# Altium schematic sheet RUMBA_plus.SchDoc
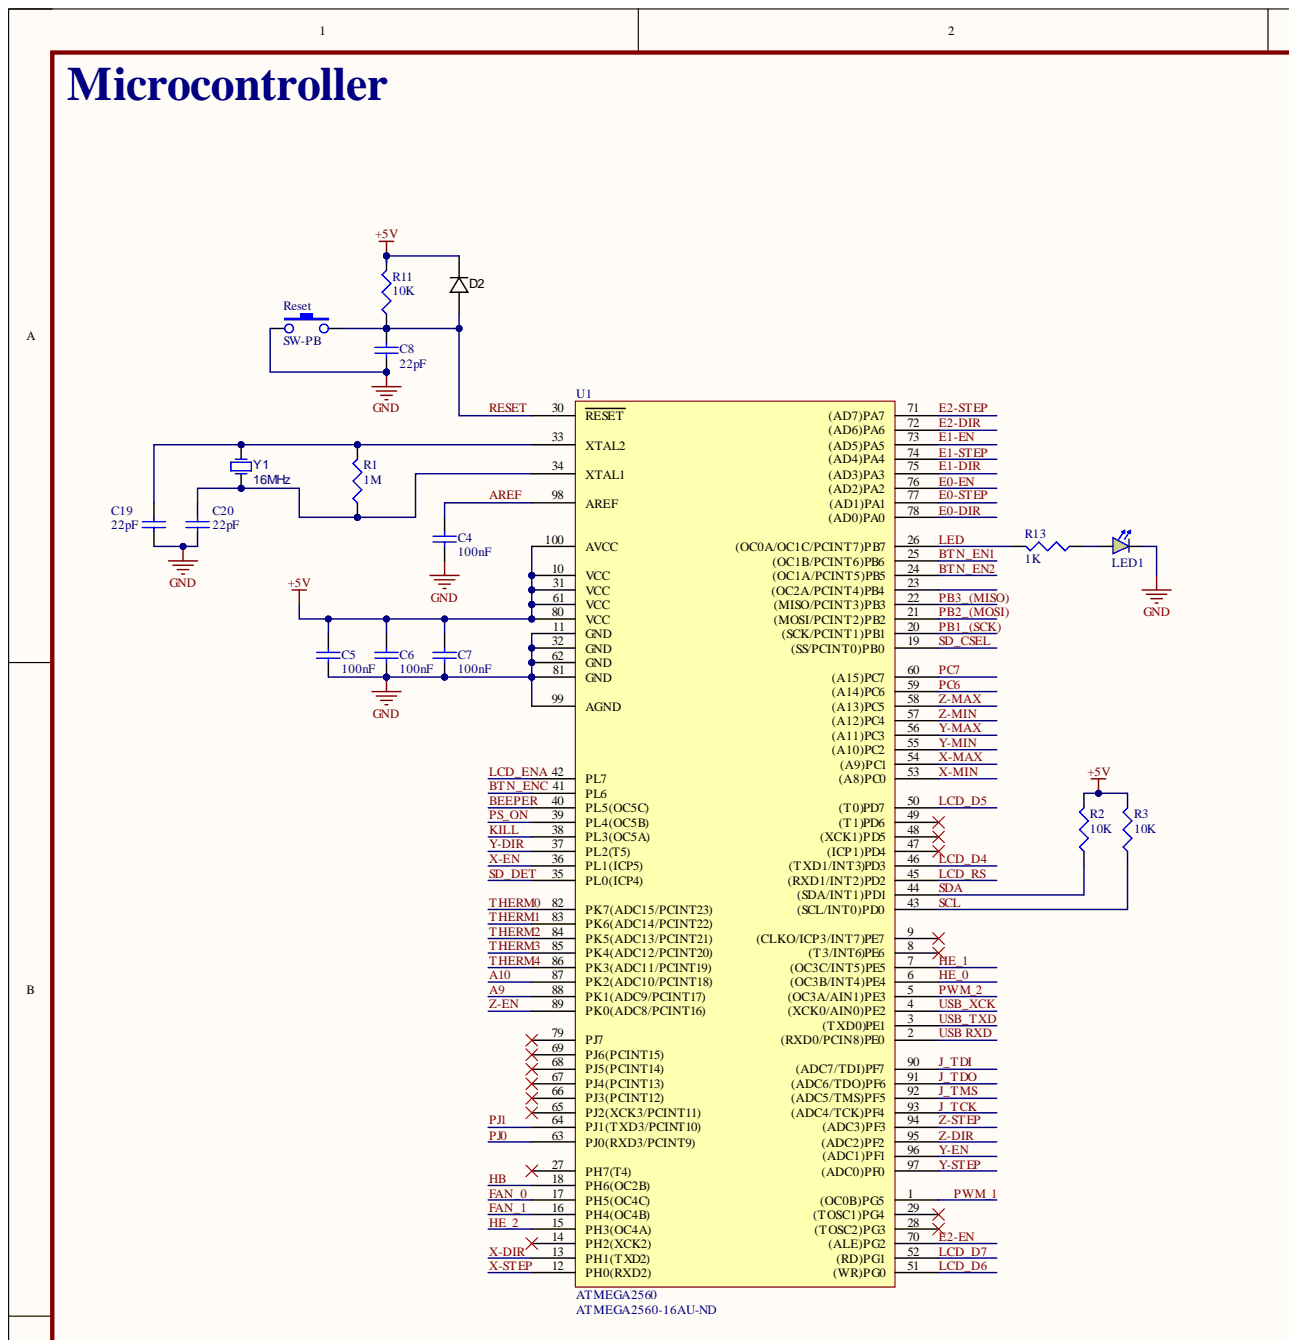
[diagram p0: RUMBA_plus.SchDoc, top left]
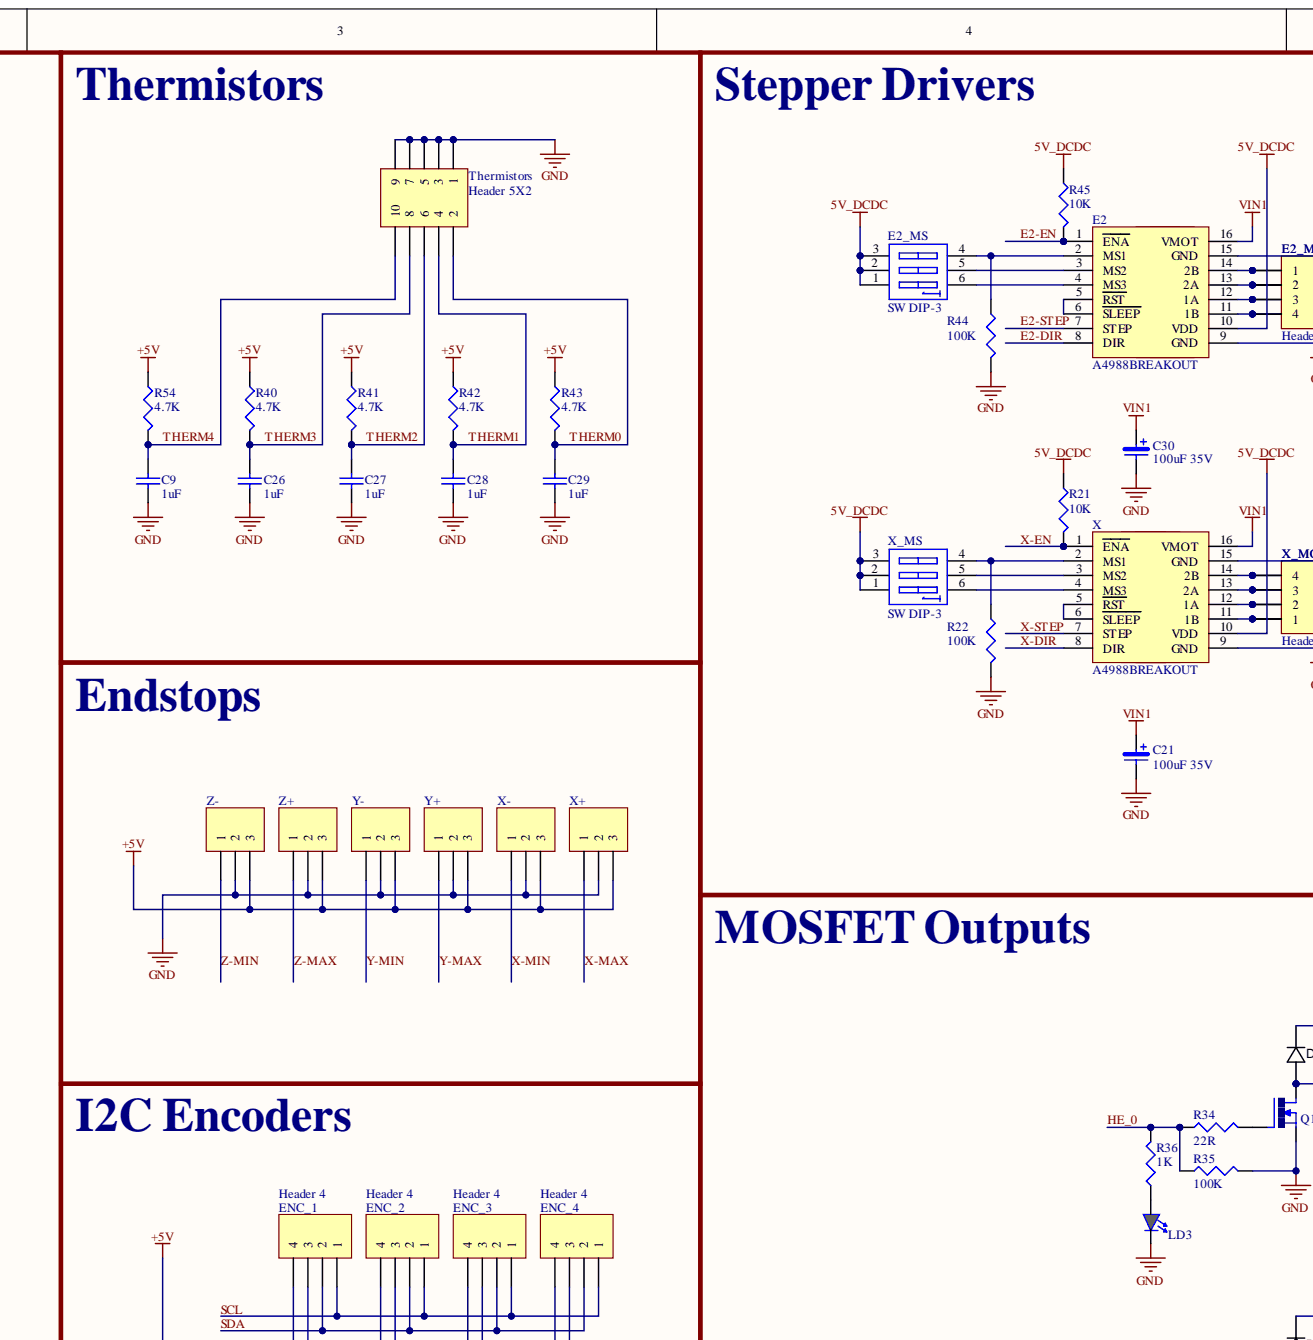
[diagram p1: RUMBA_plus.SchDoc, top centre]
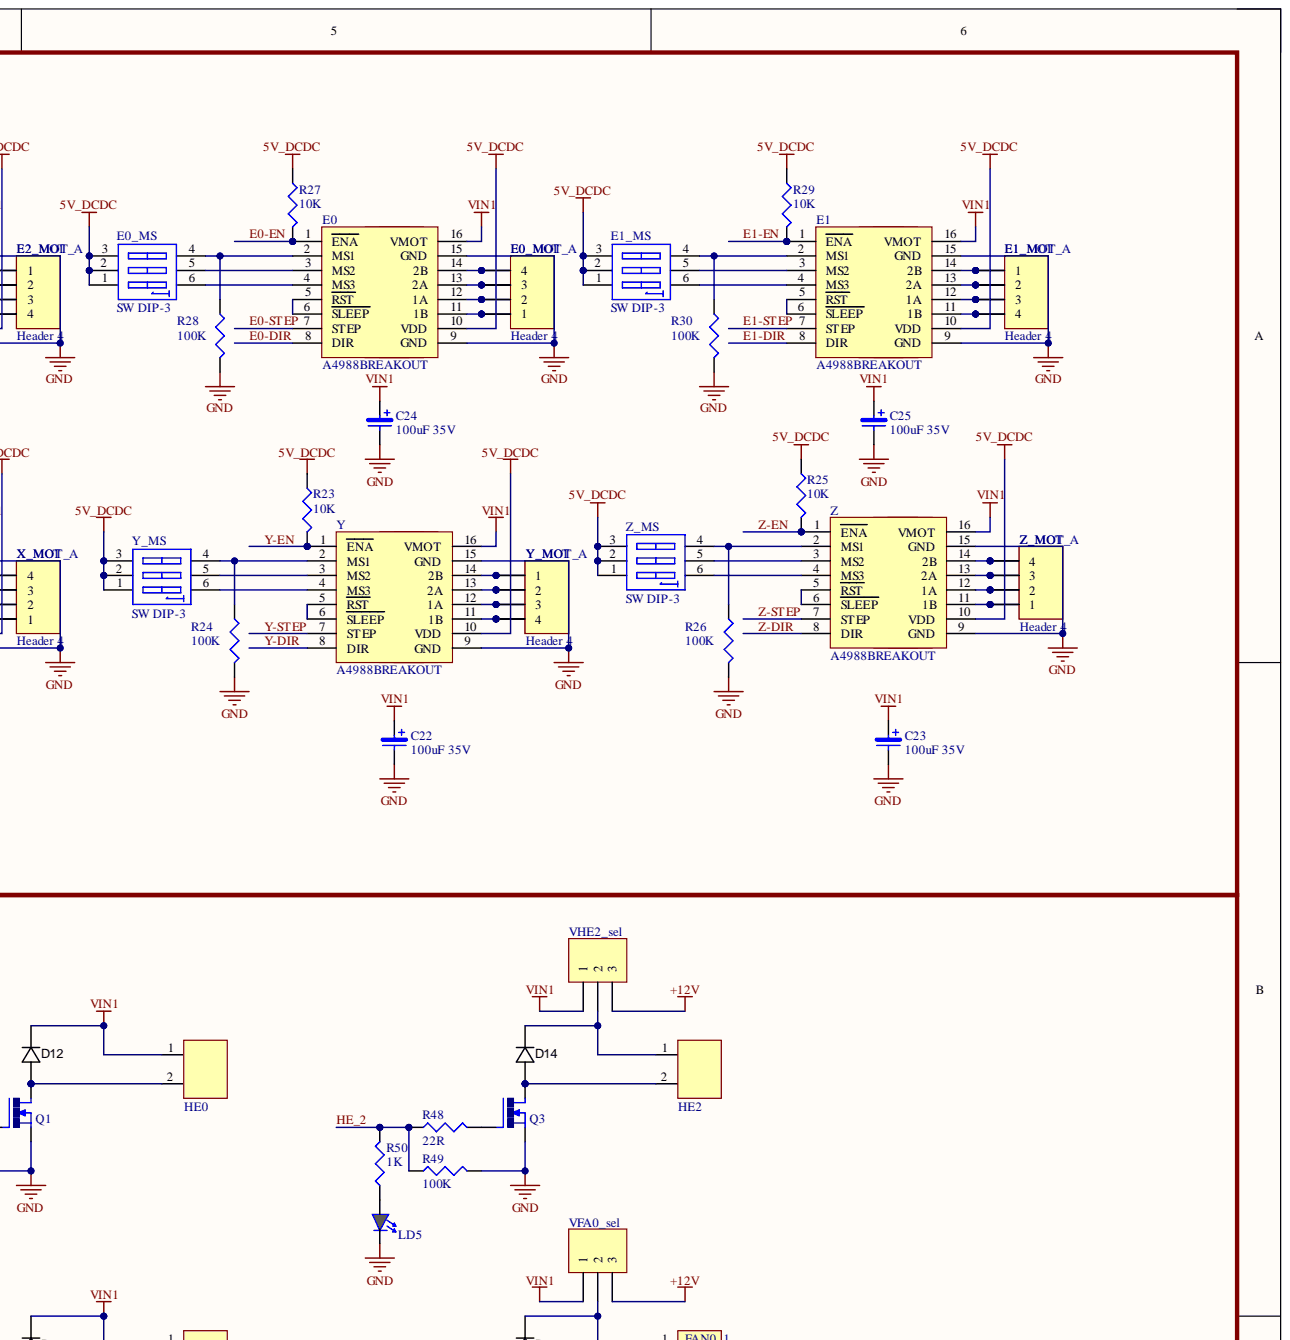
[diagram p2: RUMBA_plus.SchDoc, top right]
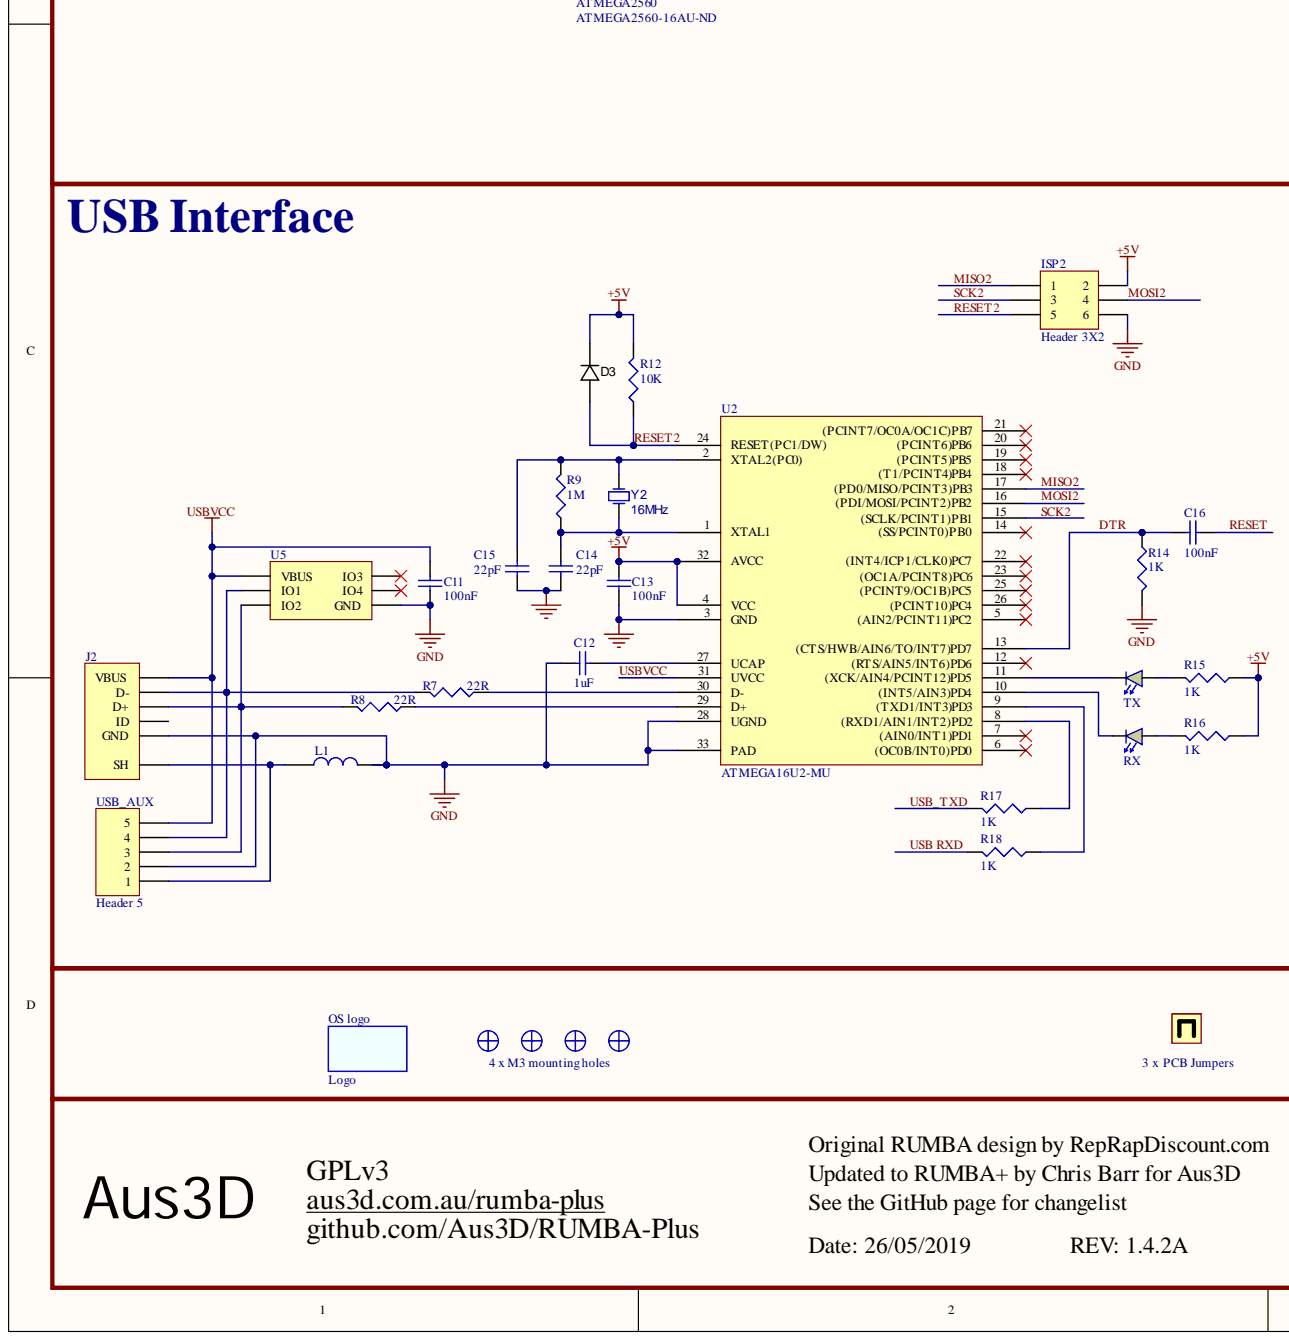
[diagram p3: RUMBA_plus.SchDoc, bottom left]
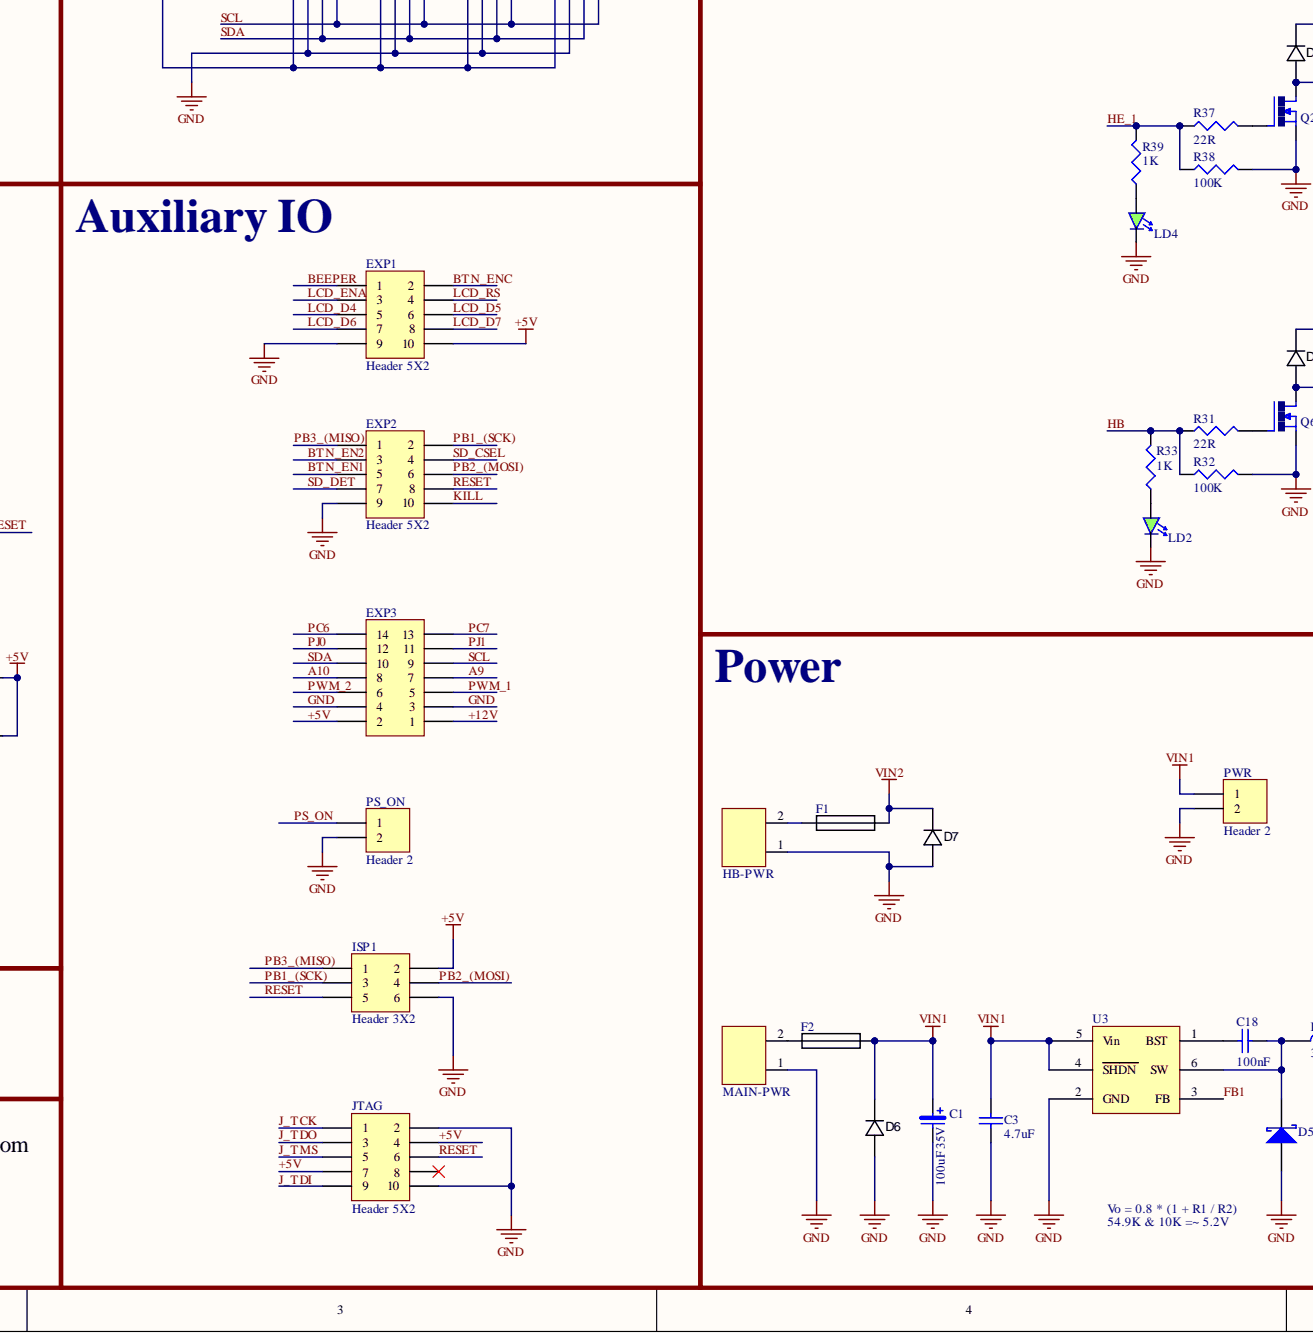
[diagram p4: RUMBA_plus.SchDoc, bottom centre]
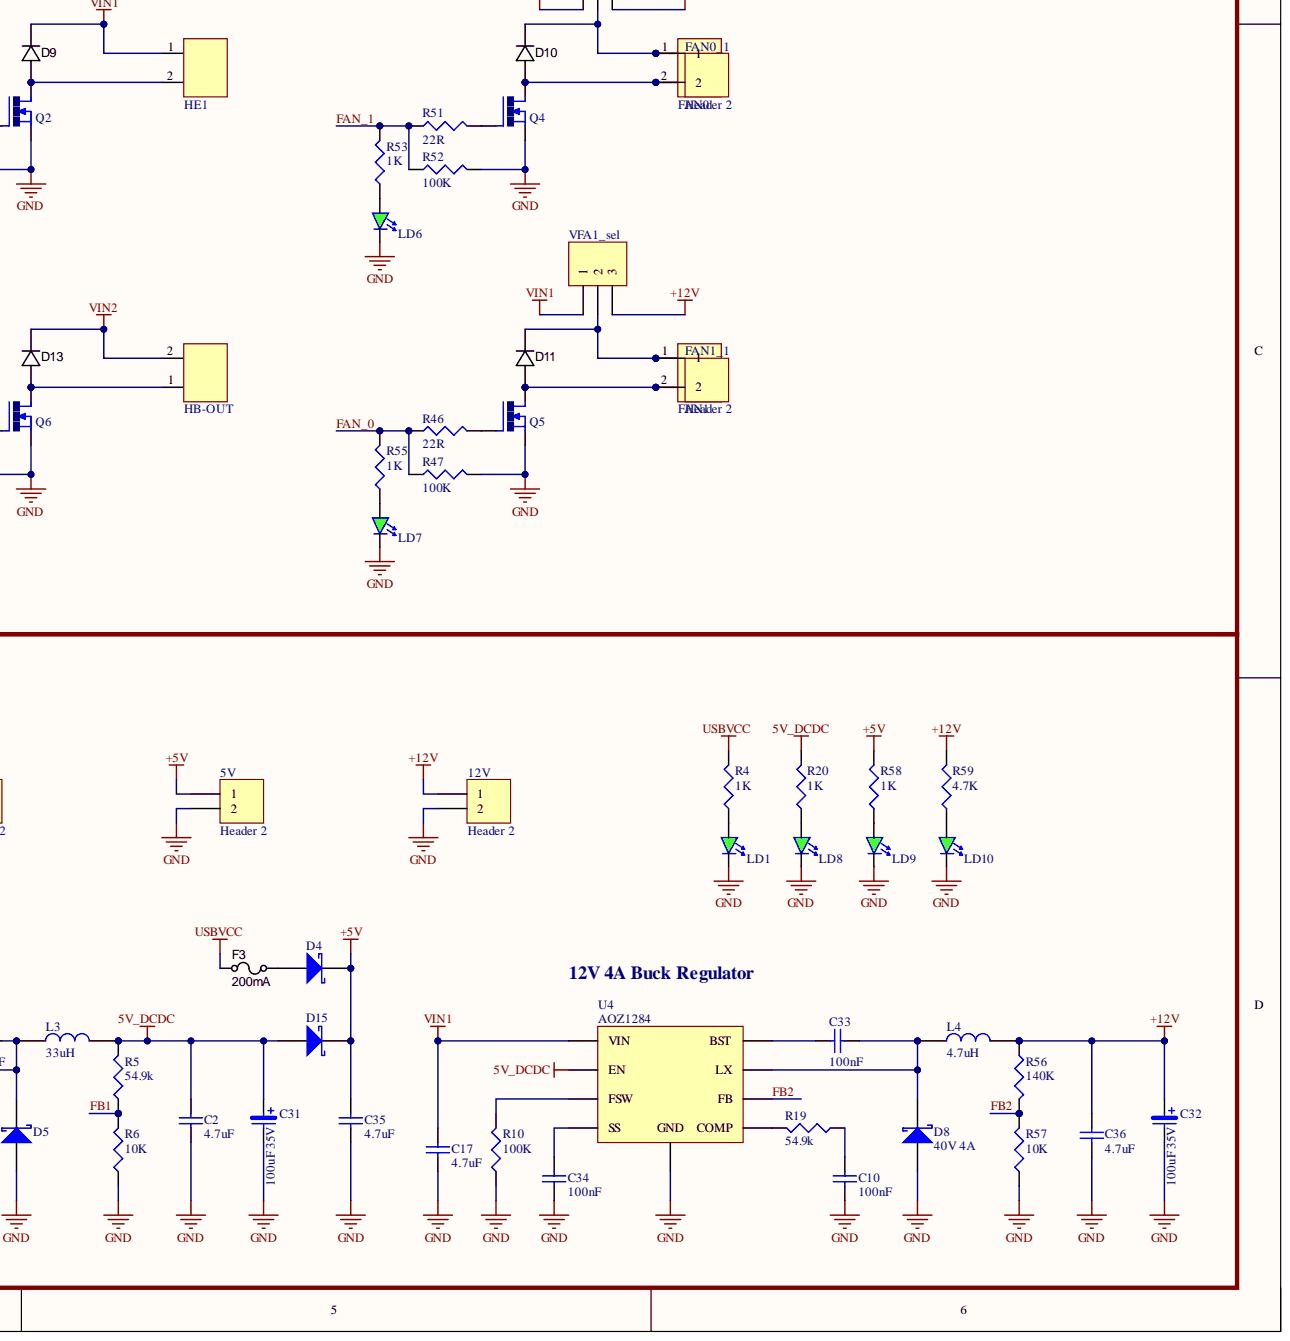
[diagram p5: RUMBA_plus.SchDoc, bottom right]

## Parts
5V  Header 2  [HDR1X2]
12V  Header 2  [HDR1X2]
C1  value 100uF 35V  [CAP EL PANASONIC]
C2  value 4.7uF  [CAPC2012X135N]
C3  value 4.7uF  [CAP 3225-1210]
C4  value 100nF  [Cap 1608-0603]
C5  value 100nF  [Cap 1608-0603]
C6  value 100nF  [Cap 1608-0603]
C7  value 100nF  [Cap 1608-0603]
C8  value 22pF  [Cap 1608-0603]
C9  value 1uF  [Cap 1608-0603]
C10  value 100nF  [Cap 1608-0603]
C11  value 100nF  [Cap 1608-0603]
C12  value 1uF  [Cap 1608-0603]
C13  value 100nF  [Cap 1608-0603]
C14  value 22pF  [Cap 1608-0603]
C15  value 22pF  [Cap 1608-0603]
C16  value 100nF  [Cap 1608-0603]
C17  value 4.7uF  [CAP 3225-1210]
C18  value 100nF  [Cap 1608-0603]
C19  value 22pF  [Cap 1608-0603]
C20  value 22pF  [Cap 1608-0603]
C21  value 100uF 35V  [CAP EL PANASONIC]
C22  value 100uF 35V  [CAP EL PANASONIC]
C23  value 100uF 35V  [CAP EL PANASONIC]
C24  value 100uF 35V  [CAP EL PANASONIC]
C25  value 100uF 35V  [CAP EL PANASONIC]
C26  value 1uF  [Cap 1608-0603]
C27  value 1uF  [Cap 1608-0603]
C28  value 1uF  [Cap 1608-0603]
C29  value 1uF  [Cap 1608-0603]
C30  value 100uF 35V  [CAP EL PANASONIC]
C31  value 100uF 35V  [CAP EL PANASONIC]
C32  value 100uF 35V  [CAP EL PANASONIC]
C33  value 100nF  [Cap 1608-0603]
C34  value 100nF  [Cap 1608-0603]
C35  value 4.7uF  [CAPC2012X135N]
C36  value 4.7uF  [CAP 3225-1210]
D2  (no value)  [SOD323]
D3  (no value)  [SOD323]
D4  (no value)  [DIOM2616X08N]
D5  (no value)  [DIOM2616X08N]
D6  (no value)  [DO-214AC]
D7  (no value)  [DO-214AC]
D8  value 40V 4A  [DO-214AA]
D9  (no value)  [DO-214AC]
D10  (no value)  [DO-214AC]
D11  (no value)  [DO-214AC]
D12  (no value)  [DO-214AC]
D13  (no value)  [DO-214AC]
D14  (no value)  [DO-214AC]
D15  (no value)  [DIOM2616X08N]
E0  A4988BREAKOUT  [A4988BREAKOUT]  (no BOM)
E0_0  (no value)  [HDR1X8-HEADER]  (mechanical)
E0_1  (no value)  [HDR1X8-HEADER]  (mechanical)
E0_MOT  Header 4  [HDR1X4]
E0_MOT_A  (no value)  [TERM4 3.5mm]
E0_MS  SW DIP-3  [dipsw SO6]
E1  A4988BREAKOUT  [A4988BREAKOUT]  (no BOM)
E1_0  (no value)  [HDR1X8-HEADER]  (mechanical)
E1_1  (no value)  [HDR1X8-HEADER]  (mechanical)
E1_MOT  Header 4  [HDR1X4]
E1_MOT_A  (no value)  [TERM4 3.5mm]
E1_MS  SW DIP-3  [dipsw SO6]
E2  A4988BREAKOUT  [A4988BREAKOUT]  (no BOM)
E2_0  (no value)  [HDR1X8-HEADER]  (mechanical)
E2_1  (no value)  [HDR1X8-HEADER]  (mechanical)
E2_MOT  Header 4  [HDR1X4]
E2_MOT_A  (no value)  [TERM4 3.5mm]
E2_MS  SW DIP-3  [dipsw SO6]
ENC_1  Header 4  [HDR1X4]
ENC_2  Header 4  [HDR1X4]
ENC_3  Header 4  [HDR1X4]
ENC_4  Header 4  [HDR1X4]
EXP1  Header 5X2  [HDR2X5_exp Iner only\]
EXP2  Header 5X2  [HDR2X5_exp Iner only\]
EXP3  Header 7X2  [HDR2X7]  (value not drawn)
F1  (no value)  [Fuseholder - BK-6013 - Mini ATC ATO Blade 15A]
F2  (no value)  [Fuseholder - BK-6013 - Mini ATC ATO Blade 15A]
F3  value 200mA  [PTC Fuse1608-0603]
FAN0  Header 2  [HDR1X2]
FAN0_1  (no value)  [TERM2 3.5mm]
FAN1  Header 2  [HDR1X2]
FAN1_1  (no value)  [TERM2 3.5mm]
HB-OUT  (no value)  [TERM2 5MM]
HB-PWR  (no value)  [TERM2 5MM]
HE0  (no value)  [TERM2 3.5mm]
HE1  (no value)  [TERM2 3.5mm]
HE2  (no value)  [TERM2 3.5mm]
ISP1  Header 3X2  [HDR2X3]
ISP2  Header 3X2  [HDR2X3]
J2  (no value)  [Micro-USB B Molex 105017-0001]
JMP
JMP
JMP
JTAG  Header 5X2  [HDR2X5]
L1  (no value)  [Res 2012-0805]
L3  value 33uH  [SRN6045TA SMD Inductor]
L4  value 4.7uH  [SRN6045TA SMD Inductor]
LD1  (no value)  [LED 1608-0603]
LD2  (no value)  [LED 1608-0603]
LD3  (no value)  [LED 1608-0603]
LD4  (no value)  [LED 1608-0603]
LD5  (no value)  [LED 1608-0603]
LD6  (no value)  [LED 1608-0603]
LD7  (no value)  [LED 1608-0603]
LD8  (no value)  [LED 1608-0603]
LD9  (no value)  [LED 1608-0603]
LD10  (no value)  [LED 1608-0603]
LED1  (no value)  [LED 1608-0603]
MAIN-PWR  (no value)  [TERM2 5MM]
OS_logo  Logo  [OS LOGO TOP]  (no BOM)
PS_ON  Header 2  [HDR1X2]
PWR  Header 2  [HDR1X2]
Q1  (no value)  [LFPACK]
Q2  (no value)  [LFPACK]
Q3  (no value)  [LFPACK]
Q4  (no value)  [LFPACK]
Q5  (no value)  [LFPACK]
Q6  (no value)  [LFPACK]
R1  value 1M  [RES 1608-0603]
R2  value 10K  [RES 1608-0603]
R3  value 10K  [RES 1608-0603]
R4  value 1K  [RES 1608-0603]
R5  value 54.9k  [RES 1608-0603]
R6  value 10K  [RES 1608-0603]
R7  value 22R  [RES 1608-0603]
R8  value 22R  [RES 1608-0603]
R9  value 1M  [RES 1608-0603]
R10  value 100K  [RES 1608-0603]
R11  value 10K  [RES 1608-0603]
R12  value 10K  [RES 1608-0603]
R13  value 1K  [RES 1608-0603]
R14  value 1K  [RES 1608-0603]
R15  value 1K  [RES 1608-0603]
R16  value 1K  [RES 1608-0603]
R17  value 1K  [RES 1608-0603]
R18  value 1K  [RES 1608-0603]
R19  value 54.9k  [RES 1608-0603]
R20  value 1K  [RES 1608-0603]
R21  value 10K  [RES 1608-0603]
R22  value 100K  [RES 1608-0603]
R23  value 10K  [RES 1608-0603]
R24  value 100K  [RES 1608-0603]
R25  value 10K  [RES 1608-0603]
R26  value 100K  [RES 1608-0603]
R27  value 10K  [RES 1608-0603]
R28  value 100K  [RES 1608-0603]
R29  value 10K  [RES 1608-0603]
R30  value 100K  [RES 1608-0603]
R31  value 22R  [RES 1608-0603]
R32  value 100K  [RES 1608-0603]
R33  value 1K  [RES 1608-0603]
R34  value 22R  [RES 1608-0603]
R35  value 100K  [RES 1608-0603]
R36  value 1K  [RES 1608-0603]
R37  value 22R  [RES 1608-0603]
R38  value 100K  [RES 1608-0603]
R39  value 1K  [RES 1608-0603]
R40  value 4.7K  [RES 1608-0603]
R41  value 4.7K  [RES 1608-0603]
R42  value 4.7K  [RES 1608-0603]
R43  value 4.7K  [RES 1608-0603]
R44  value 100K  [RES 1608-0603]
R45  value 10K  [RES 1608-0603]
R46  value 22R  [RES 1608-0603]
R47  value 100K  [RES 1608-0603]
R48  value 22R  [RES 1608-0603]
R49  value 100K  [RES 1608-0603]
R50  value 1K  [RES 1608-0603]
R51  value 22R  [RES 1608-0603]
R52  value 100K  [RES 1608-0603]
R53  value 1K  [RES 1608-0603]
R54  value 4.7K  [RES 1608-0603]
R55  value 1K  [RES 1608-0603]
R56  value 140K  [RES 1608-0603]
R57  value 10K  [RES 1608-0603]
R58  value 1K  [RES 1608-0603]
R59  value 4.7K  [RES 1608-0603]
Reset  SW-PB  [SW-PB SMD 2pin]
RX  (no value)  [LED 1608-0603]
sc1  Sraf  [Sraf 3mm - rupa 3.2]  (value not drawn)  (no BOM)
sc2  Sraf  [Sraf 3mm - rupa 3.2]  (value not drawn)  (no BOM)
sc3  Sraf  [Sraf 3mm - rupa 3.5]  (value not drawn)  (no BOM)
sc4  Sraf  [Sraf 3mm - rupa 3.5]  (value not drawn)  (no BOM)
Thermistors  Header 5X2  [HDR2X5]
TX  (no value)  [LED 1608-0603]
U1  ATMEGA2560  [TQFP100N]
U2  ATMEGA16U2-MU  [32M1-A_N]
U3  (no value)  [SOT23-6N]
U4  AOZ1284  [SOIC127P600X170_HS-9N]
U5  (no value)  [SOT23-6N]
USB_AUX  Header 5  [HDR1X5-HEADER]
VFA0_sel  Header 3  [HDR1X3]  (value not drawn)
VFA1_sel  Header 3  [HDR1X3]  (value not drawn)
VHE2_sel  Header 3  [HDR1X3]  (value not drawn)
X  A4988BREAKOUT  [A4988BREAKOUT]  (no BOM)
X+  Header 3  [HDR1X3]  (value not drawn)
X-  Header 3  [HDR1X3]  (value not drawn)
X_1  (no value)  [HDR1X8-HEADER]  (mechanical)
X_2  (no value)  [HDR1X8-HEADER]  (mechanical)
X_MOT  Header 4  [HDR1X4]
X_MOT_A  (no value)  [TERM4 3.5mm]
X_MS  SW DIP-3  [dipsw SO6]
Y  A4988BREAKOUT  [A4988BREAKOUT]  (no BOM)
Y1  16MHz  [3525 SMD Crystal]
Y2  16MHz  [3525 SMD Crystal]
Y+  Header 3  [HDR1X3]  (value not drawn)
Y-  Header 3  [HDR1X3]  (value not drawn)
Y_0  (no value)  [HDR1X8-HEADER]  (mechanical)
Y_1  (no value)  [HDR1X8-HEADER]  (mechanical)
Y_MOT  Header 4  [HDR1X4]
Y_MOT_A  (no value)  [TERM4 3.5mm]
Y_MS  SW DIP-3  [dipsw SO6]
Z  A4988BREAKOUT  [A4988BREAKOUT]  (no BOM)
Z+  Header 3  [HDR1X3]  (value not drawn)
Z-  Header 3  [HDR1X3]  (value not drawn)
Z_0  (no value)  [HDR1X8-HEADER]  (mechanical)
Z_1  (no value)  [HDR1X8-HEADER]  (mechanical)
Z_MOT  Header 4  [HDR1X4]
Z_MOT_A  (no value)  [TERM4 3.5mm]
Z_MS  SW DIP-3  [dipsw SO6]

## Nets
VIN1: C1.1 C3.2 C17.2 C21.1 C22.1 C23.1 C24.1 C25.1 C30.1 D6.K D9.K D12.K E0.16(VMOT) E1.16(VMOT) E2.16(VMOT) F2.1 HE0.1 HE1.1 PWR.1 U3.4(SHDN) U3.5(Vin) U4.9(VIN) VFA0_sel.1 VFA1_sel.1 VHE2_sel.1 X.16(VMOT) Y.16(VMOT) Z.16(VMOT)
VIN2: D7.K D13.K F1.1 HB-OUT.2
5V_DCDC: 5V.1 C2.2 C5.2 C6.2 C7.2 C13.2 C31.1 C35.2 D2.K D3.K D4.K D15.A D15.K E0.10(7_VDD) E0_MS.1 E0_MS.2 E0_MS.3 E1.10(7_VDD) E1_MS.1 E1_MS.2 E1_MS.3 E2.10(7_VDD) E2_MS.1 E2_MS.2 E2_MS.3 ENC_1.4 ENC_2.4 ENC_3.4 ENC_4.4 EXP1.10 EXP3.2 ISP1.2 ISP2.2 JTAG.4 JTAG.7 L3.2 R2.2 R3.2 R5.2 R11.2 R12.2 R15.1 R16.1 R20.2 R21.1 R23.1 R25.1 R27.1 R29.1 R40.1 R41.1 R42.1 R43.1 R45.1 R54.1 R58.2 U1.10(VCC) U1.31(VCC) U1.61(VCC) U1.80(VCC) U1.100(AVCC) U2.4(VCC) U2.32(AVCC) U4.8(EN) X.10(VDD) X+.3 X-.3 X_MS.1 X_MS.2 X_MS.3 Y.10(7_VDD) Y+.3 Y-.3 Y_MS.1 Y_MS.2 Y_MS.3 Z.10(7_VDD) Z+.3 Z-.3 Z_MS.1 Z_MS.2 Z_MS.3  [also labelled +5V]
+12V: 12V.1 C32.1 C36.2 EXP3.1 L4.2 R56.1 R59.2 VFA0_sel.3 VFA1_sel.3 VHE2_sel.3
A9: EXP3.7 U1.88(PK1(ADC9/PCINT17))
A10: EXP3.8 U1.87(PK2(ADC10/PCINT18))
AREF: C4.2 U1.98(AREF)
BEEPER: EXP1.1 U1.40(PL5(OC5C))
BTN_EN1: EXP2.5 U1.25((OC1B/PCINT6)PB6)
BTN_EN2: EXP2.3 U1.24((OC1A/PCINT5)PB5)
BTN_ENC: EXP1.2 U1.41(PL6)
DTR: C16.2 R14.1 U2.13((CT_S/HWB/AIN6/TO/INT7)PD7)
E0-DIR: E0.8(DIR) U1.78((AD0)PA0)
E0-EN: E0.1(ENA) R27.2 U1.76((AD2)PA2)
E0-STEP: E0.7(ST_EP) U1.77((AD1)PA1)
E1-DIR: E1.8(DIR) U1.75((AD3)PA3)
E1-EN: E1.1(ENA) R29.2 U1.73((AD5)PA5)
E1-STEP: E1.7(ST_EP) U1.74((AD4)PA4)
E2-DIR: E2.8(DIR) U1.72((AD6)PA6)
E2-EN: E2.1(ENA) R45.2 U1.70((ALE)PG2)
E2-STEP: E2.7(ST_EP) U1.71((AD7)PA7)
FAN_0: R46.1 R47.1 R55.2 U1.17(PH5(OC4C))
FAN_1: R51.1 R52.1 R53.2 U1.16(PH4(OC4B))
FB1: R5.1 R6.2 U3.3(FB)
FB2: R56.2 R57.2 U4.6(FB)
GND: 5V.2 12V.2 C1.2 C2.1 C3.1 C4.1 C5.1 C6.1 C7.1 C8.1 C9.2 C10.2 C11.1 C12.2 C13.1 C14.1 C15.1 C17.1 C19.1 C20.1 C21.2 C22.2 C23.2 C24.2 C25.2 C26.2 C27.2 C28.2 C29.2 C30.2 C31.2 C32.2 C34.1 C35.1 C36.1 D5.A D6.A D7.A D8.A E0.9(8_GND) E0.15(2_GND) E1.9(8_GND) E1.15(2_GND) E2.9(8_GND) E2.15(2_GND) ENC_1.3 ENC_2.3 ENC_3.3 ENC_4.3 EXP1.9 EXP2.9 EXP3.3 EXP3.4 HB-PWR.1 ISP1.6 ISP2.6 J2.GND JTAG.2 JTAG.10 L1.2 LD1.2 LD2.2 LD3.2 LD4.2 LD5.2 LD6.2 LD7.2 LD8.2 LD9.2 LD10.2 LED1.2 MAIN-PWR.1 PS_ON.2 PWR.2 Q1.3 Q2.3 Q3.3 Q4.3 Q5.3 Q6.3 R6.1 R10.1 R14.2 R22.2 R24.2 R26.2 R28.2 R30.2 R32.2 R35.2 R38.2 R44.2 R47.2 R49.2 R52.2 R57.1 Reset.1 Thermistors.1 Thermistors.3 Thermistors.5 Thermistors.7 Thermistors.9 U1.11(GND) U1.32(GND) U1.62(GND) U1.81(GND) U1.99(AGND) U2.3(GND) U2.28(UGND) U2.33(PAD) U3.2(GND) U4.3 U5.2(GND) USB_AUX.2 X.9(GND) X.15(GND) X+.2 X-.2 Y.9(8_GND) Y.15(2_GND) Y+.2 Y-.2 Z.9(8_GND) Z.15(2_GND) Z+.2 Z-.2
HB: R31.1 R32.1 R33.2 U1.18(PH6(OC2B))
HE_0: R34.1 R35.1 R36.2 U1.6((OC3B/INT4)PE4)
HE_1: R37.1 R38.1 R39.2 U1.7((OC3C/INT5)PE5)
HE_2: R48.1 R49.1 R50.2 U1.15(PH3(OC4A))
J_TCK: JTAG.1 U1.93((ADC4/TCK)PF4)
J_TDI: JTAG.9 U1.90((ADC7/TDI)PF7)
J_TDO: JTAG.3 U1.91((ADC6/TDO)PF6)
J_TMS: JTAG.5 U1.92((ADC5/TMS)PF5)
KILL: EXP2.10 U1.38(PL3(OC5A))
LCD_D4: EXP1.5 U1.46((T_XD1/INT3)PD3)
LCD_D5: EXP1.6 U1.50((T_0)PD7)
LCD_D6: EXP1.7 U1.51((WR)PG0)
LCD_D7: EXP1.8 U1.52((RD)PG1)
LCD_ENA: EXP1.3 U1.42(PL7)
LCD_RS: EXP1.4 U1.45((RXD1/INT2)PD2)
LED: R13.2 U1.26((OC0A/OC1C/PCINT7)PB7)
MISO2: ISP2.1 U2.17((PD0/MISO/PCINT3)PB3)
MOSI2: ISP2.4 U2.16((PDI/MOSI/PCINT2)PB2)
PB1_(SCK): EXP2.2 ISP1.3 U1.20((SCK/PCINT1)PB1)
PB2_(MOSI): EXP2.6 ISP1.4 U1.21((MOSI/PCINT2)PB2)
PB3_(MISO): EXP2.1 ISP1.1 U1.22((MISO/PCINT3)PB3)
PC6: EXP3.14 U1.59((A14)PC6)
PC7: EXP3.13 U1.60((A15)PC7)
PJ0: EXP3.12 U1.63(PJ0(RXD3/PCINT9))
PJ1: EXP3.11 U1.64(PJ1(T_XD3/PCINT10))
PS_ON: PS_ON.1 U1.39(PL4(OC5B))
PWM_1: EXP3.5 U1.1((OC0B)PG5)
PWM_2: EXP3.6 U1.5((OC3A/AIN1)PE3)
RESET: C8.2 C16.1 D2.A EXP2.8 ISP1.5 JTAG.6 R11.1 Reset.2 U1.30(RESET)
RESET2: D3.A ISP2.5 R12.1 U2.24(RESET_(PC1/DW))
SCK2: ISP2.3 U2.15((SCLK/PCINT1)PB1)
SCL: ENC_1.1 ENC_2.1 ENC_3.1 ENC_4.1 EXP3.9 R3.1 U1.43((SCL/INT0)PD0)
SD_CSEL: EXP2.4 U1.19((SS/PCINT0)PB0)
SD_DET: EXP2.7 U1.35(PL0(ICP4))
SDA: ENC_1.2 ENC_2.2 ENC_3.2 ENC_4.2 EXP3.10 R2.1 U1.44((SDA/INT1)PD1)
THERM0: C29.1 R43.2 Thermistors.2 U1.82(PK7(ADC15/PCINT23))
THERM1: C28.1 R42.2 Thermistors.4 U1.83(PK6(ADC14/PCINT22))
THERM2: C27.1 R41.2 Thermistors.6 U1.84(PK5(ADC13/PCINT21))
THERM3: C26.1 R40.2 Thermistors.8 U1.85(PK4(ADC12/PCINT20))
THERM4: C9.1 R54.2 Thermistors.10 U1.86(PK3(ADC11/PCINT19))
USB RXD: R18.2 U1.2((RXD0/PCIN8)PE0)
USB_TXD: R17.2 U1.3((T_XD0)PE1)
USB_XCK: U1.4((XCK0/AIN0)PE2)
USBVCC: C11.2 F3.1 J2.VBUS R4.2 U2.31(UVCC) U5.5(VBUS) USB_AUX.5
X-DIR: U1.13(PH1(T_XD2)) X.8(DIR)
X-EN: R21.2 U1.36(PL1(ICP5)) X.1(ENA_1)
X-MAX: U1.54((A9)PC1) X+.1
X-MIN: U1.53((A8)PC0) X-.1
X-STEP: U1.12(PH0(RXD2)) X.7(ST_EP_7)
Y-DIR: U1.37(PL2(T5)) Y.8(DIR)
Y-EN: R23.2 U1.96((ADC1)PF1) Y.1(ENA)
Y-MAX: U1.56((A11)PC3) Y+.1
Y-MIN: U1.55((A10)PC2) Y-.1
Y-STEP: U1.97((ADC0)PF0) Y.7(ST_EP)
Z-DIR: U1.95((ADC2)PF2) Z.8(DIR)
Z-EN: R25.2 U1.89(PK0(ADC8/PCINT16)) Z.1(ENA)
Z-MAX: U1.58((A13)PC5) Z+.1
Z-MIN: U1.57((A12)PC4) Z-.1
Z-STEP: U1.94((ADC3)PF3) Z.7(ST_EP)
NetC10_1: C10.1 R19.1
NetC12_1: C12.1 U2.27(UCAP)
NetC14_2: C14.2 R9.1 U2.1(XTAL1) Y2.1
NetC15_2: C15.2 R9.2 U2.2(XTAL2(PC0)) Y2.3
NetC18_1: C18.1 D5.K L3.1 U3.6(SW)
NetC18_2: C18.2 U3.1(BST)
NetC19_2: C19.2 R1.2 U1.33(XTAL2) Y1.3
NetC20_2: C20.2 R1.1 U1.34(XTAL1) Y1.1
NetC33_1: C33.1 U4.2(BST)
NetC33_2: C33.2 D8.K L4.1 U4.1(LX)
NetC34_2: C34.2 U4.7(SS)
NetD4_A: D4.A F3.2
NetD9_A: D9.A HE1.2 Q2.2
NetD10_A: D10.A FAN0.2 FAN0_1.2 Q4.2
NetD10_K: D10.K FAN0.1 FAN0_1.1 VFA0_sel.2
NetD11_A: D11.A FAN1.2 FAN1_1.2 Q5.2
NetD11_K: D11.K FAN1.1 FAN1_1.1 VFA1_sel.2
NetD12_A: D12.A HE0.2 Q1.2
NetD13_A: D13.A HB-OUT.1 Q6.2
NetD14_A: D14.A HE2.2 Q3.2
NetD14_K: D14.K HE2.1 VHE2_sel.2
NetE0_2: E0.2(MS1) E0_MS.4 R28.1
NetE0_3: E0.3(MS2) E0_MS.5
NetE0_4: E0.4(MS3) E0_MS.6
NetE0_5: E0.5(RST) E0.6(SLEEP)
NetE0_11: E0.11(1B) E0_MOT.1 E0_MOT_A.4
NetE0_12: E0.12(1A) E0_MOT.2 E0_MOT_A.3
NetE0_13: E0.13(2A) E0_MOT.3 E0_MOT_A.2
NetE0_14: E0.14(2B) E0_MOT.4 E0_MOT_A.1
NetE1_2: E1.2(MS1) E1_MS.4 R30.1
NetE1_3: E1.3(MS2) E1_MS.5
NetE1_4: E1.4(MS3) E1_MS.6
NetE1_5: E1.5(RST) E1.6(SLEEP)
NetE1_11: E1.11(1B) E1_MOT.1 E1_MOT_A.4
NetE1_12: E1.12(1A) E1_MOT.2 E1_MOT_A.3
NetE1_13: E1.13(2A) E1_MOT.3 E1_MOT_A.2
NetE1_14: E1.14(2B) E1_MOT.4 E1_MOT_A.1
NetE2_2: E2.2(MS1) E2_MS.4 R44.1
NetE2_3: E2.3(MS2) E2_MS.5
NetE2_4: E2.4(MS3) E2_MS.6
NetE2_5: E2.5(RST) E2.6(SLEEP)
NetE2_11: E2.11(1B) E2_MOT.1 E2_MOT_A.4
NetE2_12: E2.12(1A) E2_MOT.2 E2_MOT_A.3
NetE2_13: E2.13(2A) E2_MOT.3 E2_MOT_A.2
NetE2_14: E2.14(2B) E2_MOT.4 E2_MOT_A.1
NetF1_0: F1.0 HB-PWR.2
NetF2_0: F2.0 MAIN-PWR.2
NetJ2_D+: J2.D+ R8.2 U5.3(IO2) USB_AUX.3
NetJ2_D-: J2.D- R7.2 U5.1(IO1) USB_AUX.4
NetJ2_SHIELD: J2.SHIELD(SH) L1.1 USB_AUX.1
NetLD1_1: LD1.1 R4.1
NetLD2_1: LD2.1 R33.1
NetLD3_1: LD3.1 R36.1
NetLD4_1: LD4.1 R39.1
NetLD5_1: LD5.1 R50.1
NetLD6_1: LD6.1 R53.1
NetLD7_1: LD7.1 R55.1
NetLD8_1: LD8.1 R20.1
NetLD9_1: LD9.1 R58.1
NetLD10_1: LD10.1 R59.1
NetLED1_1: LED1.1 R13.1
NetQ1_1: Q1.1 R34.2
NetQ2_1: Q2.1 R37.2
NetQ3_1: Q3.1 R48.2
NetQ4_1: Q4.1 R51.2
NetQ5_1: Q5.1 R46.2
NetQ6_1: Q6.1 R31.2
NetR7_1: R7.1 U2.30(D-)
NetR8_1: R8.1 U2.29(D+)
NetR10_2: R10.2 U4.4(FSW)
NetR15_2: R15.2 TX.1
NetR16_2: R16.2 RX.1
NetR17_1: R17.1 U2.8((RXD1/AIN1/INT2)PD2)
NetR18_1: R18.1 U2.9((T_XD1/INT3)PD3)
NetR19_2: R19.2 U4.5(COMP)
NetR22_1: R22.1 X.2(MS1) X_MS.4
NetR24_1: R24.1 Y.2(MS1) Y_MS.4
NetR26_1: R26.1 Z.2(MS1) Z_MS.4
NetRX_2: RX.2 U2.10((INT5/AIN3)PD4)
NetTX_2: TX.2 U2.11((XCK/AIN4/PCINT12)PD5)
NetX_3: X.3(MS2) X_MS.5
NetX_4: X.4(MS3) X_MS.6
NetX_5: X.5(RST) X.6(SLEEP)
NetX_11: X.11(1B) X_MOT.1 X_MOT_A.4
NetX_12: X.12(1A) X_MOT.2 X_MOT_A.3
NetX_13: X.13(2A) X_MOT.3 X_MOT_A.2
NetX_14: X.14(2B) X_MOT.4 X_MOT_A.1
NetY_3: Y.3(MS2) Y_MS.5
NetY_4: Y.4(MS3) Y_MS.6
NetY_5: Y.5(RST) Y.6(SLEEP)
NetY_11: Y.11(1B) Y_MOT.1 Y_MOT_A.4
NetY_12: Y.12(1A) Y_MOT.2 Y_MOT_A.3
NetY_13: Y.13(2A) Y_MOT.3 Y_MOT_A.2
NetY_14: Y.14(2B) Y_MOT.4 Y_MOT_A.1
NetZ_3: Z.3(MS2) Z_MS.5
NetZ_4: Z.4(MS3) Z_MS.6
NetZ_5: Z.5(RST) Z.6(SLEEP)
NetZ_11: Z.11(1B) Z_MOT.1 Z_MOT_A.4
NetZ_12: Z.12(1A) Z_MOT.2 Z_MOT_A.3
NetZ_13: Z.13(2A) Z_MOT.3 Z_MOT_A.2
NetZ_14: Z.14(2B) Z_MOT.4 Z_MOT_A.1

Not connected: E0_0 1 2 3 4 5 6 7 8; E0_1 1 2 3 4 5 6 7 8; E1_0 1 2 3 4 5 6 7 8; E1_1 1 2 3 4 5 6 7 8; E2_0 1 2 3 4 5 6 7 8; E2_1 1 2 3 4 5 6 7 8; J2 ID; JTAG 8; U1 8((T_3/INT6)PE6) 9((CLKO/ICP3/INT7)PE7) 14(PH2(XCK2)) 23((OC2A/PCINT4)PB4) 27(PH7(T4)) 28((T_OSC2)PG3) 29((T_OSC1)PG4) 47((ICP1)PD4) 48((XCK1)PD5) 49((T_1)PD6) 65(PJ2(XCK3/PCINT11)) 66(PJ3(PCINT12)) 67(PJ4(PCINT13)) 68(PJ5(PCINT14)) 69(PJ6(PCINT15)) 79(PJ7); U2 5((AIN2/PCINT11)PC2) 6((OC0B/INT0)PD0) 7((AIN0/INT1)PD1) 12((RT_S/AIN5/INT6)PD6) 14((SS/PCINT0)PB0) 18((T_1/PCINT4)PB4) 19((PCINT5)PB5) 20((PCINT6)PB6) 21((PCINT7/OC0A/OC1C)PB7) 22((INT4/ICP1/CLK0)PC7) 23((OC1A/PCINT8)PC6) 25((PCINT9/OC1B)PC5) 26((PCINT10)PC4); U5 4(IO3) 6(IO4); X_1 1 2 3 4 5 6 7(EP_7) 8; X_2 1 2 3 4 5 6 7 8; Y_0 1 2 3 4 5 6 7 8; Y_1 1 2 3 4 5 6 7 8; Z_0 1 2 3 4 5 6 7 8; Z_1 1 2 3 4 5 6 7 8
